# Altium schematic sheet STM_.SchDoc(STM_)
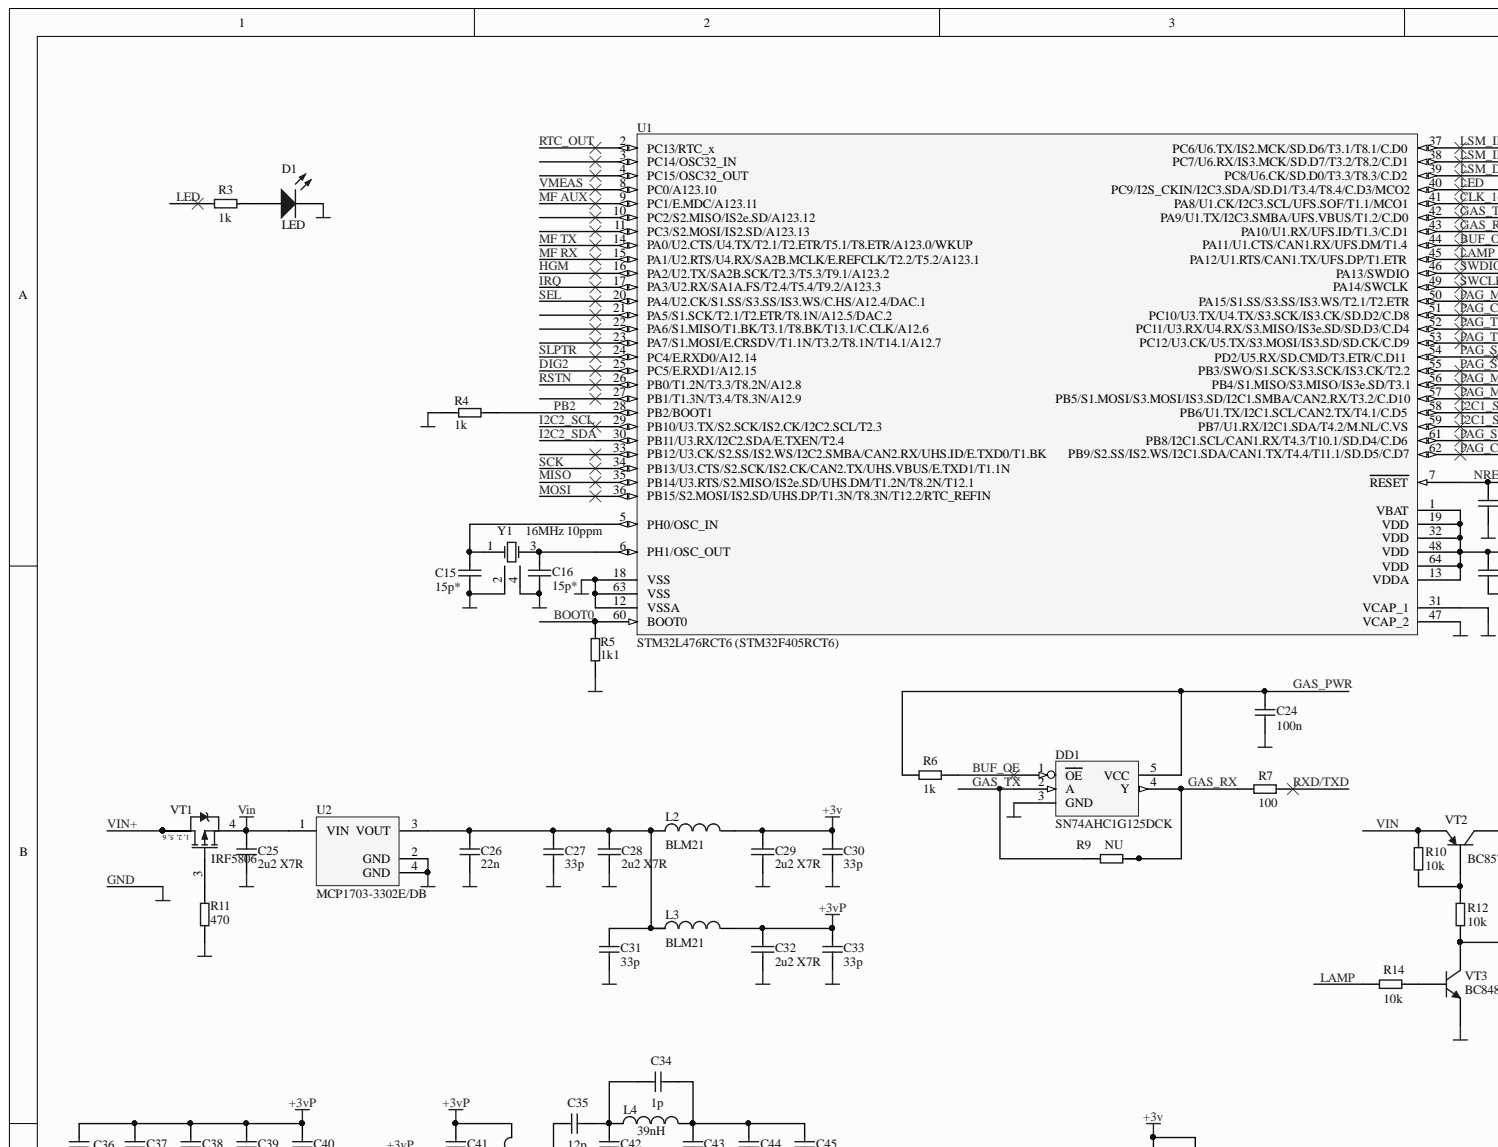
[diagram p0: STM_.SchDoc, top left]
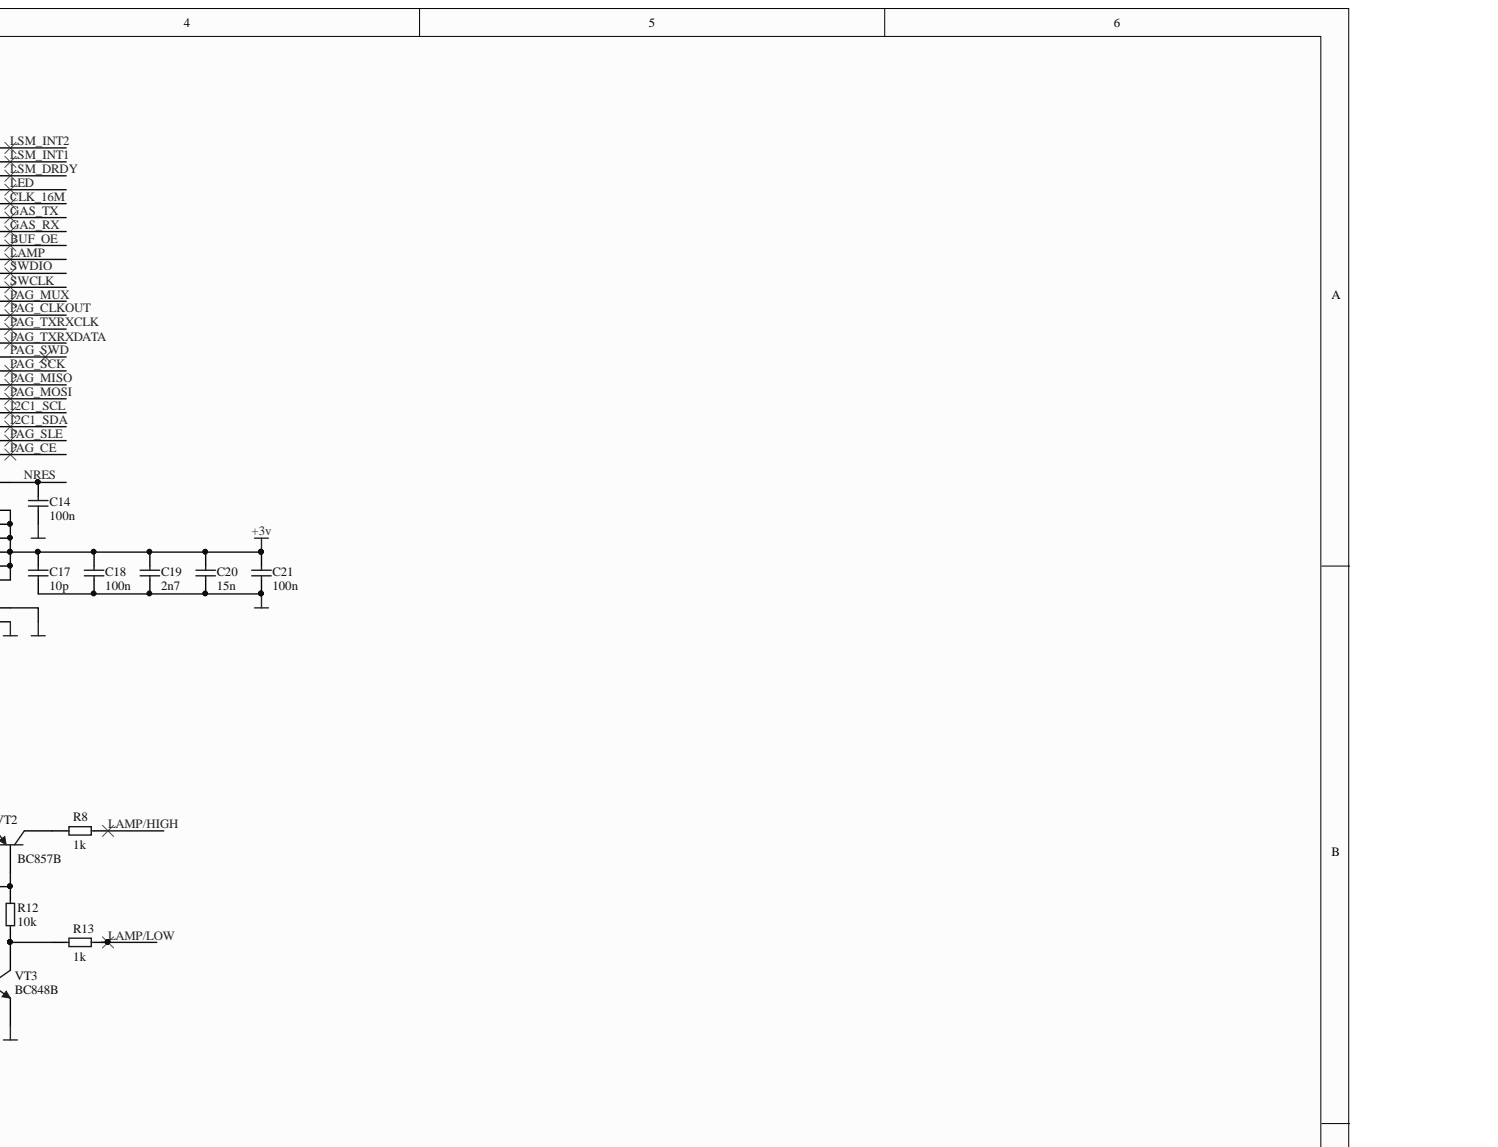
[diagram p1: STM_.SchDoc, top right]
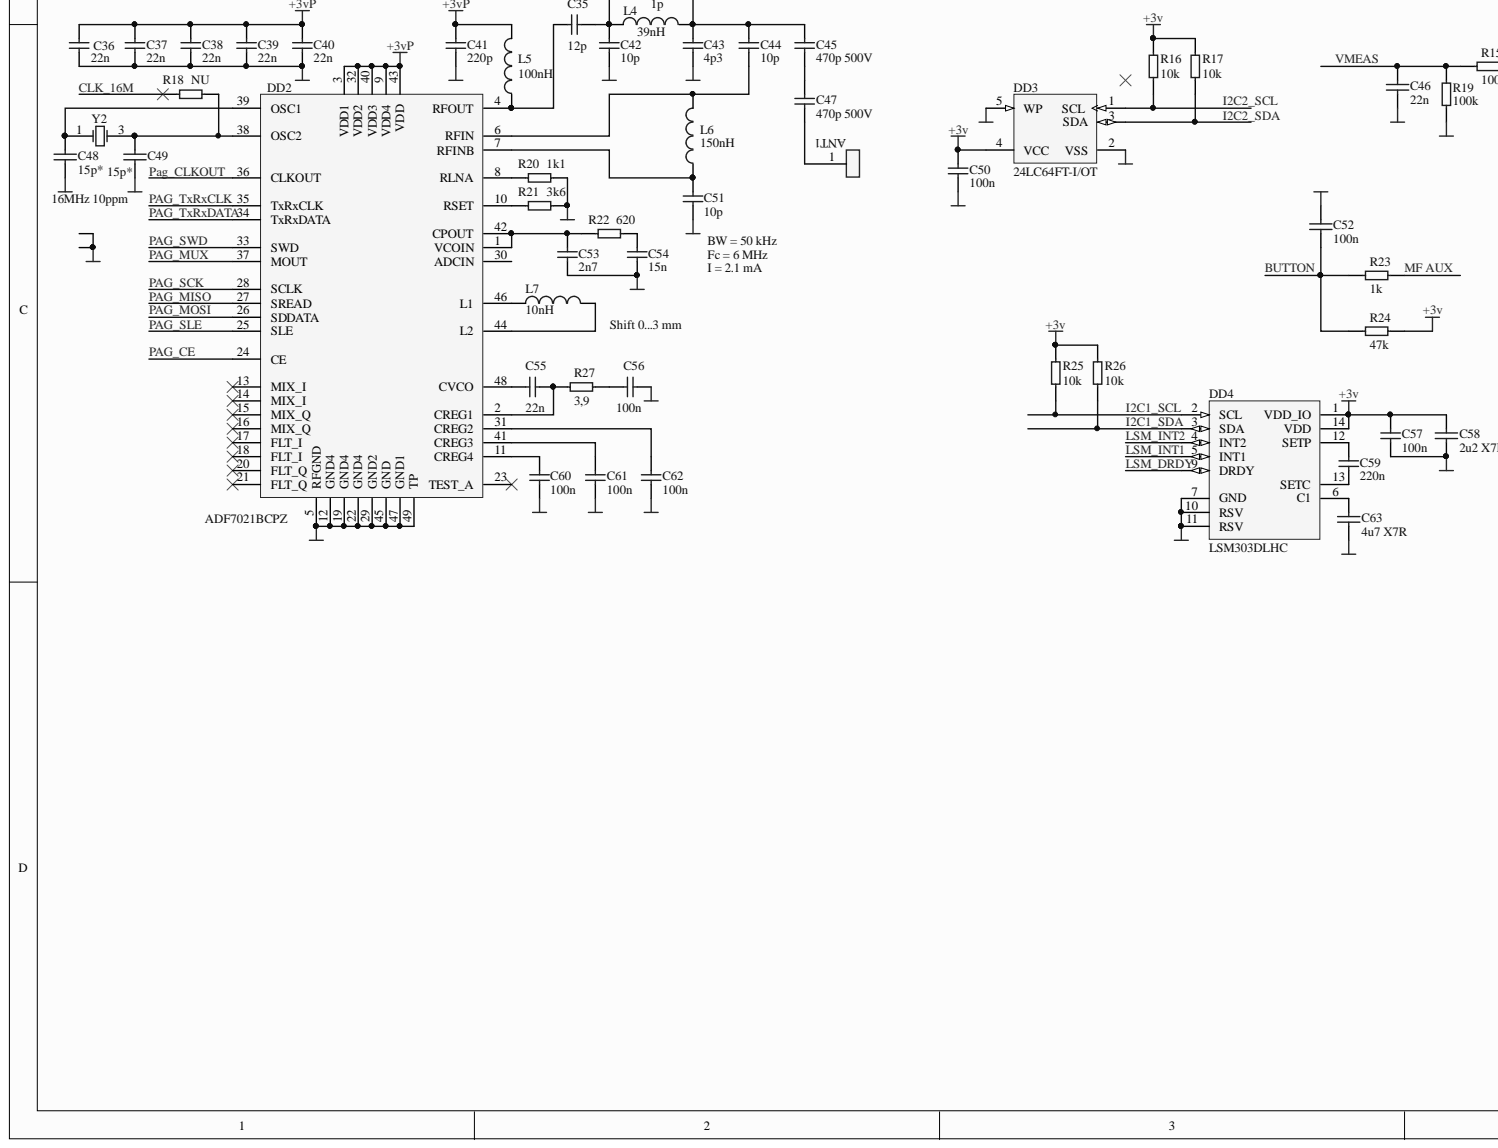
[diagram p2: STM_.SchDoc, bottom left]
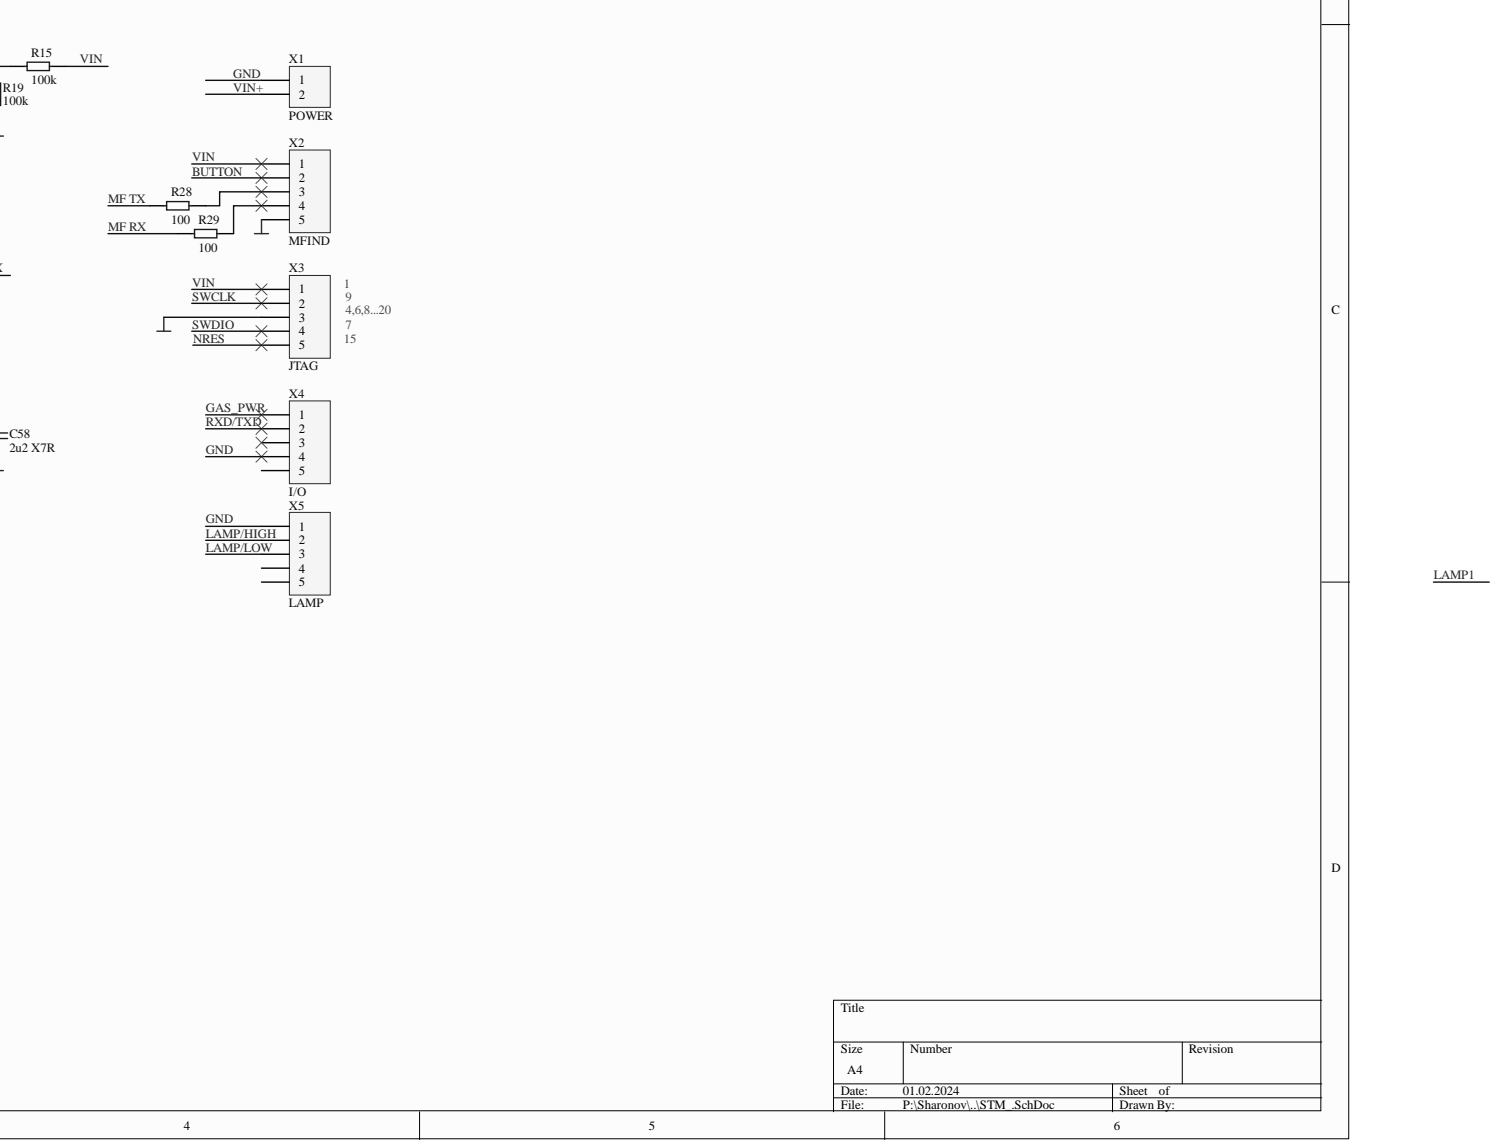
[diagram p3: STM_.SchDoc, bottom right]

## Parts
ANT1  TEST POINT  [JUMPER2000]  (value not drawn)  (no BOM)
C14  100n  [CAPC1608L]
C15  15p*  [CAPC1608L]
C16  15p*  [CAPC1608L]
C17  10p  [CAPC1608L]
C18  100n  [CAPC1608L]
C19  2n7  [CAPC1608L]
C20  15n  [CAPC1608L]
C21  100n  [CAPC1608L]
C24  100n  [CAPC1608L]
C25  2u2 X7R  [CAPC2012L]
C26  22n  [CAPC1608L]
C27  33p  [CAPC1608L]
C28  2u2 X7R  [CAPC2012L]
C29  2u2 X7R  [CAPC2012L]
C30  33p  [CAPC1608L]
C31  33p  [CAPC1608L]
C32  2u2 X7R  [CAPC2012L]
C33  33p  [CAPC1608L]
C34  1p  [CAPC1608L]
C35  12p  [CAPC1608L]
C36  22n  [CAPC1608L]
C37  22n  [CAPC1608L]
C38  22n  [CAPC1608L]
C39  22n  [CAPC1608L]
C40  22n  [CAPC1608L]
C41  220p  [CAPC1608L]
C42  10p  [CAPC1608L]
C43  4p3  [CAPC1608L]
C44  10p  [CAPC1608L]
C45  470p 500V  [CAPC3216M]
C46  22n  [CAPC1608L]
C47  470p 500V  [CAPC3216M]
C48  15p*  [CAPC1608L]
C49  15p*  [CAPC1608L]
C50  100n  [CAPC1608L]
C51  10p  [CAPC1608L]
C52  100n  [CAPC1608L]
C53  2n7  [CAPC1608L]
C54  15n  [CAPC1608L]
C55  22n  [RESC1608L]
C56  100n  [CAPC1608L]
C57  100n  [CAPC1608L]
C58  2u2 X7R  [CAPC2012L]
C59  220n  [CAPC1608L]
C60  100n  [RESC1608L]
C61  100n  [CAPC1608L]
C62  100n  [CAPC1608L]
C63  4u7 X7R  [CAPC1608L]
D1  LED  [INDC1608L]
DD1  SN74AHC1G125DCK  [SOT353-5L]
DD2  ADF7021BCPZ  [QFNadf50P700X700X60-48N]
DD3  24LC64FT-I/OT  [SOT-23-OT5_N]
DD4  LSM303DLHC  [LGA-14]
L2  BLM21  [INDC2012L New]
L3  BLM21  [INDC2012L New]
L4  39nH  [INDC2012L New]
L5  100nH  [INDC2012L New]
L6  150nH  [INDC2012L New]
L7  10nH  [INDC2012L New]
R3  1k  [RESC1608L]
R4  1k  [CAPC1608L]
R5  1k1  [RESC1608L]
R6  1k  [RESC1608L]
R7  100  [RESC6332]
R8  1k  [RESC1608L]
R9  NU  [RESC1608L]
R10  10k  [RESC1608L]
R11  470  [RESC1608L]
R12  10k  [RESC1608L]
R13  1k  [RESC1608L]
R14  10k  [RESC1608L]
R15  100k  [RESC1608L]
R16  10k  [RESC1608L]
R17  10k  [RESC1608L]
R18  NU  [RESC1608L]
R19  100k  [RESC1608L]
R20  1k1  [RESC1608L]
R21  3k6  [RESC1608L]
R22  620  [RESC1608L]
R23  1k  [RESC1608L]
R24  47k  [RESC1608L]
R25  10k  [RESC1608L]
R26  10k  [RESC1608L]
R27  3,9  [RESC1608L]
R28  100  [RESC6332]
R29  100  [RESC6332]
U1  STM32L476RCT6 (STM32F405RCT6)  [LQFP64_STM]
U2  MCP1703-3302E/DB  [SOT223_M]
VT1  IRF5806  [TSOP95P280X145-6N]
VT2  BC857B  [SOT-23]
VT3  BC848B  [SOT-23]
X1  POWER  [JST B2B-PH-K]
X2  MFIND  [JST B5B-PH-K]  (no BOM)
X3  JTAG  [HDR1X5]  (no BOM)
X4  I/O  [JST B4B-PH-K]  (no BOM)
X5  LAMP  [JST B3B-PH-K]  (no BOM)
Y1  16MHz 10ppm  [NX3225S-4]
Y2  16MHz 10ppm  [NX3225A]

## Nets
+3v: C17.1 C18.1 C19.1 C20.1 C21.1 C29.1 C30.1 C50.1 C57.1 C58.1 DD3.4(VCC) DD4.1(VDD_IO) DD4.14(VDD) L2.2 R16.1 R17.1 R24.2 R25.1 R26.1 U1.1(VBAT) U1.13(VDDA) U1.19(VDD) U1.32(VDD) U1.48(VDD) U1.64(VDD)
+3vP: C32.1 C33.1 C36.1 C37.1 C38.1 C39.1 C40.1 C41.1 DD2.3(VDD1) DD2.9(VDD4) DD2.32(VDD2) DD2.40(VDD3) DD2.43(VDD) L3.2 L5.2
BOOT0: R5.1 U1.60(BOOT0)
BUF_OE: DD1.1(OE) R6.1 U1.44(PA11/U1.CTS/CAN1.RX/UFS.DM/T1.4)
BUTTON: C52.2 R23.1 R24.1 X2.2
CLK_16M: R18.2 U1.41(PA8/U1.CK/I2C3.SCL/UFS.SOF/T1.1/MCO1)
DIG2: U1.25(PC5/E.RXD1/A12.15)
GAS_PWR: C24.1 DD1.5(VCC) R6.2 X4.1
GAS_RX: DD1.4(Y) R7.2 R9.1 U1.43(PA10/U1.RX/UFS.ID/T1.3/C.D1)
GAS_TX: DD1.2(A) R9.2 U1.42(PA9/U1.TX/I2C3.SMBA/UFS.VBUS/T1.2/C.D0)
GND: C14.2 C15.2 C16.2 C17.2 C18.2 C19.2 C20.2 C21.2 C24.2 C25.2 C26.2 C27.2 C28.2 C29.2 C30.2 C31.2 C32.2 C33.2 C36.2 C37.2 C38.2 C39.2 C40.2 C41.2 C42.2 C43.2 C46.2 C48.2 C49.2 C50.2 C51.2 C52.1 C53.2 C54.2 C56.1 C57.2 C58.2 C60.2 C61.2 C62.2 C63.2 D1.1 DD1.3(GND) DD2.5(RFGND) DD2.12(GND4) DD2.19(GND4) DD2.22(GND4) DD2.29(GND2) DD2.45(GND) DD2.47(GND1) DD2.49(TP) DD3.2(VSS) DD3.5(WP) DD4.7(GND) DD4.10(RSV) DD4.11(RSV) R4.1 R5.2 R11.2 R19.2 R20.1 R21.1 U1.12(VSSA) U1.18(VSS) U1.31(VCAP_1) U1.47(VCAP_2) U1.63(VSS) U2.2(GND) U2.4(GND) VT3.2 X1.1 X2.5 X3.3 X4.4 X5.1 Y1.2 Y1.4 Y2.2 Y2.4
HGM: U1.16(PA2/U2.TX/SA2B.SCK/T2.3/T5.3/T9.1/A123.2)
I2C1_SCL: DD4.2(SCL) R25.2 U1.58(PB6/U1.TX/I2C1.SCL/CAN2.TX/T4.1/C.D5)
I2C1_SDA: DD4.3(SDA) R26.2 U1.59(PB7/U1.RX/I2C1.SDA/T4.2/M.NL/C.VS)
I2C2_SCL: DD3.1(SCL) R16.2 U1.29(PB10/U3.TX/S2.SCK/IS2.CK/I2C2.SCL/T2.3)
I2C2_SDA: DD3.3(SDA) R17.2 U1.30(PB11/U3.RX/I2C2.SDA/E.TXEN/T2.4)
IRQ: U1.17(PA3/U2.RX/SA1A.FS/T2.4/T5.4/T9.2/A123.3)
LAMP: R14.2 U1.45(PA12/U1.RTS/CAN1.TX/UFS.DP/T1.ETR)
LAMP/HIGH: R8.1 X5.2
LAMP/LOW: R13.1 X5.3
LED: R3.2 U1.40(PC9/I2S_CKIN/I2C3.SDA/SD.D1/T3.4/T8.4/C.D3/MCO2)
LSM_DRDY: DD4.9(DRDY) U1.39(PC8/U6.CK/SD.D0/T3.3/T8.3/C.D2)
LSM_INT1: DD4.5(INT1) U1.38(PC7/U6.RX/IS3.MCK/SD.D7/T3.2/T8.2/C.D1)
LSM_INT2: DD4.4(INT2) U1.37(PC6/U6.TX/IS2.MCK/SD.D6/T3.1/T8.1/C.D0)
MF AUX: R23.2 U1.9(PC1/E.MDC/A123.11)
MF RX: R29.1 U1.15(PA1/U2.RTS/U4.RX/SA2B.MCLK/E.REFCLK/T2.2/T5.2/A123.1)
MF TX: R28.1 U1.14(PA0/U2.CTS/U4.TX/T2.1/T2.ETR/T5.1/T8.ETR/A123.0/WKUP)
MISO: U1.35(PB14/U3.RTS/S2.MISO/IS2e.SD/UHS.DM/T1.2N/T8.2N/T12.1)
MOSI: U1.36(PB15/S2.MOSI/IS2.SD/UHS.DP/T1.3N/T8.3N/T12.2/RTC_REFIN)
NRES: C14.1 U1.7(RESET) X3.5
PAG_CE: DD2.24(CE) U1.62(PB9/S2.SS/IS2.WS/I2C1.SDA/CAN1.TX/T4.4/T11.1/SD.D5/C.D7)
Pag_CLKOUT: DD2.36(CLKOUT) U1.51(PC10/U3.TX/U4.TX/S3.SCK/IS3.CK/SD.D2/C.D8)
PAG_MISO: DD2.27(SREAD) U1.56(PB4/S1.MISO/S3.MISO/IS3e.SD/T3.1)
PAG_MOSI: DD2.26(SDDATA) U1.57(PB5/S1.MOSI/S3.MOSI/IS3.SD/I2C1.SMBA/CAN2.RX/T3.2/C.D10)
PAG_MUX: DD2.37(MOUT) U1.50(PA15/S1.SS/S3.SS/IS3.WS/T2.1/T2.ETR)
PAG_SCK: DD2.28(SCLK) U1.55(PB3/SWO/S1.SCK/S3.SCK/IS3.CK/T2.2)
PAG_SLE: DD2.25(SLE) U1.61(PB8/I2C1.SCL/CAN1.RX/T4.3/T10.1/SD.D4/C.D6)
PAG_SWD: DD2.33(SWD) U1.54(PD2/U5.RX/SD.CMD/T3.ETR/C.D11)
PAG_TxRxCLK: DD2.35(TxRxCLK) U1.52(PC11/U3.RX/U4.RX/S3.MISO/IS3e.SD/SD.D3/C.D4)
PAG_TxRxDATA: DD2.34(TxRxDATA) U1.53(PC12/U3.CK/U5.TX/S3.MOSI/IS3.SD/SD.CK/C.D9)
PB2: R4.2 U1.28(PB2/BOOT1)
RSTN: U1.26(PB0/T1.2N/T3.3/T8.2N/A12.8)
RTC_OUT: U1.2(PC13/RTC_x)
RXD/TXD: R7.1 X4.2
SCK: U1.34(PB13/U3.CTS/S2.SCK/IS2.CK/CAN2.TX/UHS.VBUS/E.TXD1/T1.1N)
SEL: U1.20(PA4/U2.CK/S1.SS/S3.SS/IS3.WS/C.HS/A12.4/DAC.1)
SLPTR: U1.24(PC4/E.RXD0/A12.14)
SWCLK: U1.49(PA14/SWCLK) X3.2
SWDIO: U1.46(PA13/SWDIO) X3.4
VIN: C25.1 R10.1 R15.2 U2.1(VIN) VT1.4 VT2.2 X2.1 X3.1
VIN+: VT1.1 VT1.2 VT1.5 VT1.6 X1.2
VMEAS: C46.1 R15.1 R19.1 U1.8(PC0/A123.10)
NetANT1_1: ANT1.1 C47.2
NetC15_1: C15.1 U1.5(PH0/OSC_IN) Y1.1
NetC16_1: C16.1 U1.6(PH1/OSC_OUT) Y1.3
NetC26_1: C26.1 C27.1 C28.1 C31.1 L2.1 L3.1 U2.3(VOUT)
NetC34_1: C34.1 C43.1 C44.1 C45.1 L4.2
NetC34_2: C34.2 C35.1 C42.1 L4.1
NetC35_2: C35.2 DD2.4(RFOUT) L5.1
NetC44_2: C44.2 DD2.6(RFIN) L6.2
NetC45_2: C45.2 C47.1
NetC48_1: C48.1 DD2.39(OSC1) Y2.1
NetC49_1: C49.1 DD2.38(OSC2) R18.1 Y2.3
NetC51_1: C51.1 DD2.7(RFINB) L6.1
NetC53_1: C53.1 DD2.1(VCOIN) DD2.42(CPOUT) R22.2
NetC54_1: C54.1 R22.1
NetC55_1: C55.1 DD2.2(CREG1) R27.2
NetC55_2: C55.2 DD2.48(CVCO)
NetC56_2: C56.2 R27.1
NetC59_1: C59.1 DD4.12(SETP)
NetC59_2: C59.2 DD4.13(SETC)
NetC60_1: C60.1 DD2.11(CREG4)
NetC61_1: C61.1 DD2.41(CREG3)
NetC62_1: C62.1 DD2.31(CREG2)
NetC63_1: C63.1 DD4.6(C1)
NetD1_2: D1.2 R3.1
NetDD2_8: DD2.8(RLNA) R20.2
NetDD2_10: DD2.10(RSET) R21.2
NetDD2_44: DD2.44(L2) L7.2
NetDD2_46: DD2.46(L1) L7.1
NetR8_2: R8.2 VT2.3
NetR10_2: R10.2 R12.1 VT2.1
NetR11_1: R11.1 VT1.3
NetR12_2: R12.2 R13.2 VT3.3
NetR14_1: R14.1 VT3.1
NetR28_2: R28.2 X2.3
NetR29_2: R29.2 X2.4
LAMP1:

Not connected: DD2 13(MIX_I) 14(MIX_I) 15(MIX_Q) 16(MIX_Q) 17(FLT_I) 18(FLT_I) 20(FLT_Q) 21(FLT_Q) 23(TEST_A) 30(ADCIN); U1 3(PC14/OSC32_IN) 4(PC15/OSC32_OUT) 10(PC2/S2.MISO/IS2e.SD/A123.12) 11(PC3/S2.MOSI/IS2.SD/A123.13) 21(PA5/S1.SCK/T2.1/T2.ETR/T8.1N/A12.5/DAC.2) 22(PA6/S1.MISO/T1.BK/T3.1/T8.BK/T13.1/C.CLK/A12.6) 23(PA7/S1.MOSI/E.CRSDV/T1.1N/T3.2/T8.1N/T14.1/A12.7) 27(PB1/T1.3N/T3.4/T8.3N/A12.9) 33(PB12/U3.CK/S2.SS/IS2.WS/I2C2.SMBA/CAN2.RX/UHS.ID/E.TXD0/T1.BK); X4 3 5; X5 4 5

## Library data of the parts
C14-C21 C24-C27 C30 C31 C33-C45 C47-C51 C53-C57 C59-C62 = Cap: Capacitor; Value: 100pF; Simulation: CAP; Signal Integrity: Cap; Published: 8-Jun-2000; PackageReference: RAD-0.3; LatestRevisionNote: Re-released for DXP Platform.; LatestRevisionDate: 17-Jul-2002; Component Kind: Standard; Publisher: Altium Limited
  C14 C17-C21 C24-C27 C30 C31 C33-C45 C47 C50 C51 C53-C57 C59-C62: Library Name: Sch components.SchLib
  C15 C16 C48 C49: Library Name: MyLibrary.IntLib
C28 C29 C32 C46 C52 C58 C63 = Cap: Capacitor; Value: 100pF; Type: Конденсатор; Simulation: CAP; Signal Integrity: Cap; Published: 8-Jun-2000; PackageReference: RAD-0.3; Library Name: MyLibrary.IntLib; LatestRevisionNote: Re-released for DXP Platform.; LatestRevisionDate: 17-Jul-2002; Component Kind: Standard; Publisher: Altium Limited
D1 = LED1: Typical RED GaAs LED; Simulation: LED1; Published: 8-Jun-2000; PackageReference: LED-1; Library Name: MyLibrary.IntLib; LatestRevisionNote: Mech Layer 1 removed.; LatestRevisionDate: 08-Jul-2005; Component Kind: Standard; Publisher: Altium Limited
DD1 = 74AHC1G125DBV: Single gate buffer; Library Name: Sch components.SchLib; Component Kind: Standard
DD2 = ADF7021: ISM Band, FSK/ASK Tranceiver IC; Library Name: Sch components.SchLib; Component Kind: Standard
DD3 = 24LC64FT-I/OT: 64Kbit, 400kHz, 2.5V, I2C Serial EEPROM, 5-Pin SOT-23, Industrial Temperature, Tape and Reel; Manufacturer: Microchip; Supplier Part Number 5: 579-24LC64FT-I/OT; Supplier Part Number 4: 08P0136; Supplier Part Number 3: 24LC64FT-I/OTDKR-ND; Supplier Part Number 2: 24LC64FT-I/OTCT-ND; Supplier Part Number 1: 24LC64FT-I/OTTR-ND; Supplier 5: Mouser; Supplier 4: Newark; Supplier 3: Digi-Key; Supplier 2: Digi-Key; Supplier 1: Digi-Key; PartNumber: 24LC64FT-I/OT; PackageVersion: revBB, Aug-2009; PackageReference: SOT-23-OT5; PackageDescription: 5-Lead Plastic Small Outline Transistor (OT) [SOT-23]; Mounting Technology: Surface Mount; Library Name: Microchip I2C Serial EEPROM.IntLib; DatasheetVersion: revA, May-2009; ComponentLink3URL: http://www.microchip.com/stellent/groups/techpub_sg/documents/packagingspec/en012702.pdf; ComponentLink3Description: Package Specification; ComponentLink2URL: http://ww1.microchip.com/downloads/en/DeviceDoc/22154A.pdf; ComponentLink2Description: Datasheet; ComponentLink1URL: http://www.microchip.com/; ComponentLink1Description: Manufacturer URL; Component Kind: Standard; Code_IPC: SOT23-5
DD4 = LSM303DLHC: 3D accelerometer and 3D magnetometer module; Library Name: Sch components.SchLib; Component Kind: Standard
L2-L7 = INDUCTOR: Type: Индуктивность; PCB3D: INDC1608-0603_0805_0_0000_L; Library Name: MyLibrary.IntLib; Component Kind: Standard
R3 R4 R6 R8 R13 R23 = Res: Resistor; Value: 1K; Simulation: RESISTOR; Signal Integrity: Res; Published: 8-Jun-2000; PackageReference: J1-0603; Library Name: Sch components.SchLib; LatestRevisionNote: PCB Footprint replaced.; LatestRevisionDate: 04-Mar-2005; Component Kind: Standard; Publisher: Altium Limited
R5 R7 R9-R12 R14-R22 R24-R29 = Res: Resistor; Value: 1K; Simulation: RESISTOR; Signal Integrity: Res; Published: 8-Jun-2000; PackageReference: J1-0603; Library Name: Sch components.SchLib; LatestRevisionNote: PCB Footprint replaced.; LatestRevisionDate: 04-Mar-2005; Component Kind: Standard; Publisher: Altium Limited
U1 = STM32F405RCT6: Cortex-M4F  MCU, 64 pin, 168 MHz, 256/512 KB Flash, 192+4 KB RAM; Library Name: Sch components.SchLib; Component Kind: Standard
U2 = MCP1703-3302E/DB: 250 mA, 16V, Low Quiescent Current LDO Regulator, 3-Pin SOT-223, Extended Temperature; Supplier Part Number 3: 40M0623; Supplier Part Number 2: 1627177; Supplier Part Number 1: MCP1703-3302E/DB-ND; Supplier 3: Newark; Supplier 2: Farnell; Supplier 1: Digi-Key; PackageVersion: revBB, Aug-2009; PackageReference: SOT-223-DB3; PackageDescription: 3-Lead Plastic Small Outline Transistor (DB) [SOT-223]; Library Name: Microchip Power Mgt Line Regulator.IntLib; FirstPublished: 29-Jan-2009; DatasheetVersion: revD, Sep-2009; ComponentLink3URL: http://www.microchip.com/; ComponentLink3Description: Microchip.com Home; ComponentLink2URL: http://www.microchip.com/stellent/groups/techpub_sg/documents/packagingspec/en012702.pdf; ComponentLink2Description: Packaging Specification; ComponentLink1URL: http://ww1.microchip.com/downloads/en/DeviceDoc/22049d.pdf; ComponentLink1Description: Datasheet; Component Kind: Standard; Publisher: Altium Limited
VT1 = IRF5803: HEXFET Power MOSFET; Simulation: IRF5803; Published: 17-Sep-2003; PackageVersion: Jul-2002; PackageReference: TSOP-6; PackageDescription: SOT-457/SC-74; 6 Leads; Body 3.1 x 2.9 mm, inc. leads (LxW max); Library Name: IR Discrete MOSFET - Power.IntLib; DatasheetVersion: 5-Mar-2001; ComponentLink2URL: http://www.irf.com/product-info/datasheets/data/IRF5803.pdf; ComponentLink2Description: Datasheet; ComponentLink1URL: http://www.irf.com/; ComponentLink1Description: Manufacturer Link; Component Kind: Standard; Code_JEDEC: MO-193AA; Publisher: Altium Limited
VT2 = BC857B: PNP General Purpose Amplifier; Type: Транзистор; Simulation: BC857B; Signal Integrity: BC857B; Published: 8-Jun-2000; PackageReference: SOT-23A; PackageDocument: Sep-1998; Library Name: FSC Discrete BJT.IntLib; LatestRevisionNote: Re-released for DXP Platform.; LatestRevisionDate: 17-Jul-2002; DatasheetDocument: 1997; ComponentLink2URL: http://www.fairchildsemi.com/ds/BC%2FBC857.pdf; ComponentLink2Description: Datasheet; ComponentLink1URL: http://www.fairchildsemi.com/; ComponentLink1Description: Manufacturer Link; Component Kind: Standard; Publisher: Altium Limited
VT3 = BC848BLT1: General Purpose Transistor NPN Silicon; Type: Транзистор; Simulation: BC848BLT1; Signal Integrity: BC848BLT1; Published: 29-Sep-2000; PackageVersion: 1997; PackageReference: 318-08; PackageDescription: SOT-23; 3 Leads; Body 3.0 x 2.6 mm, inc. leads (LxW max); Library Name: Motorola Discrete BJT.IntLib; LatestRevisionNote: Re-released for DXP Platform.; LatestRevisionDate: 17-Jul-2002; DatasheetVersion: 1997; ComponentLink2URL: http://www.onsemi.com/pub/Collateral/BC846ALT1-D.PDF; ComponentLink2Description: Datasheet; ComponentLink1URL: http://www.onsemi.com/site/products/landing?taxonomyID=194; ComponentLink1Description: Manufacturer Link; Component Kind: Standard; Publisher: Altium Limited
X1 = Header 2: Header, 2-Pin; Signal Integrity: Connector; Library Name: Miscellaneous Connectors.IntLib; LatestRevisionNote: Re-released for DXP Platform.; LatestRevisionDate: 17-Jul-2002; Component Kind: Standard; Publisher: Altium Limited
X2-X5 = Header 5: Header, 5-Pin; Signal Integrity: Connector; Library Name: Miscellaneous Connectors.IntLib; LatestRevisionNote: Re-released for DXP Platform.; LatestRevisionDate: 17-Jul-2002; Publisher: Altium Limited
Y1 = XTAL_SMD: Crystal Oscillator; Simulation: XTAL; Published: 8-Jun-2000; PackageReference: R38; Library Name: MySchlib1.SchLib; LatestRevisionNote: Re-released for DXP Platform.; LatestRevisionDate: 17-Jul-2002; Component Kind: Standard; Publisher: Altium Limited
Y2 = XTAL: Quartz Crystal 3.2mm x 2.5mm; Value: 16Mhz; Type: Кварцевый резонатор; Simulation: XTAL; Published: 8-Jun-2000; PackageReference: R38; Library Name: MyLibrary.IntLib; LatestRevisionNote: Re-released for DXP Platform.; LatestRevisionDate: 17-Jul-2002; Component Kind: Standard; Publisher: Altium Limited
ANT1 = TEST POINT: Type: Пин; Library Name: MauPLib.IntLib
